# Altium schematic sheet USB.SchDoc(USB)
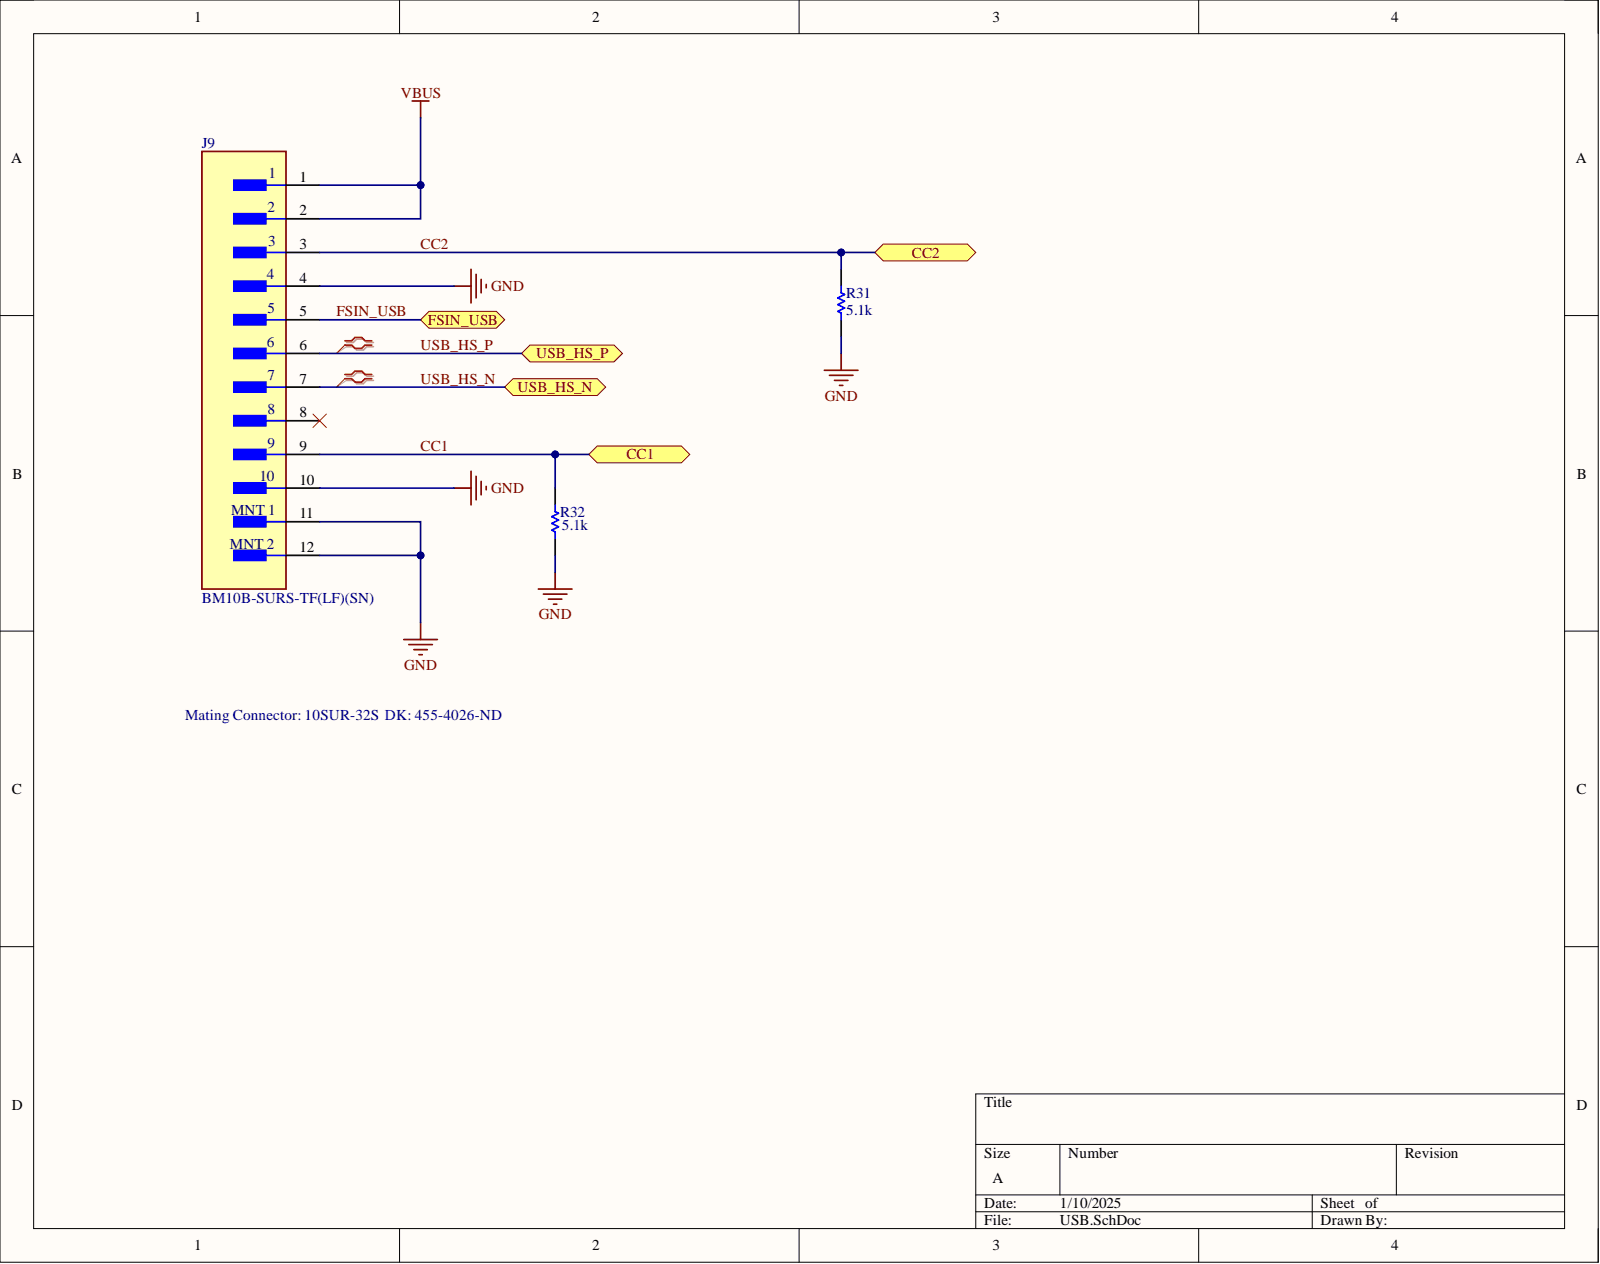
[diagram p0: USB.SchDoc]

## Parts
J9  BM10B-SURS-TF(LF)(SN)
R31  5.1k
R32  5.1k

## Ports
CC1, CC2, FSIN_USB, USB_HS_N, USB_HS_P

## Nets
GND: J9.4 J9.10 J9.11 J9.12 R31.1 R32.1
VBUS: J9.1 J9.2
CC1: J9.9 R32.2 ; port CC1
CC2: J9.3 R31.2 ; port CC2
FSIN_USB: J9.5 ; port FSIN_USB
USB_HS_N: J9.7 ; port USB_HS_N
USB_HS_P: J9.6 ; port USB_HS_P

Not connected: J9 8

## Library data of the parts
J9 = CMP-17439-000608-1: CONN HEADER SMD 10POS 0.8MM; Number of Poles: 10; Case/Package: SMD/SMT; Component Kind: Standard; Height: 2.7mm; Lead Free: Lead Free; Length: 10.2mm; Library Name: Altium Content Vault; Pitch: 0.8mm; Width: 2mm
R31 R32 = CMP-2002-07753-1: Manufacturer: Yageo / Phycomp; Part Number: RC0402FR-075K1L; Component Kind: Standard; Library Name: Altium Content Vault
